# Altium schematic sheet Power.SchDoc(Designator)
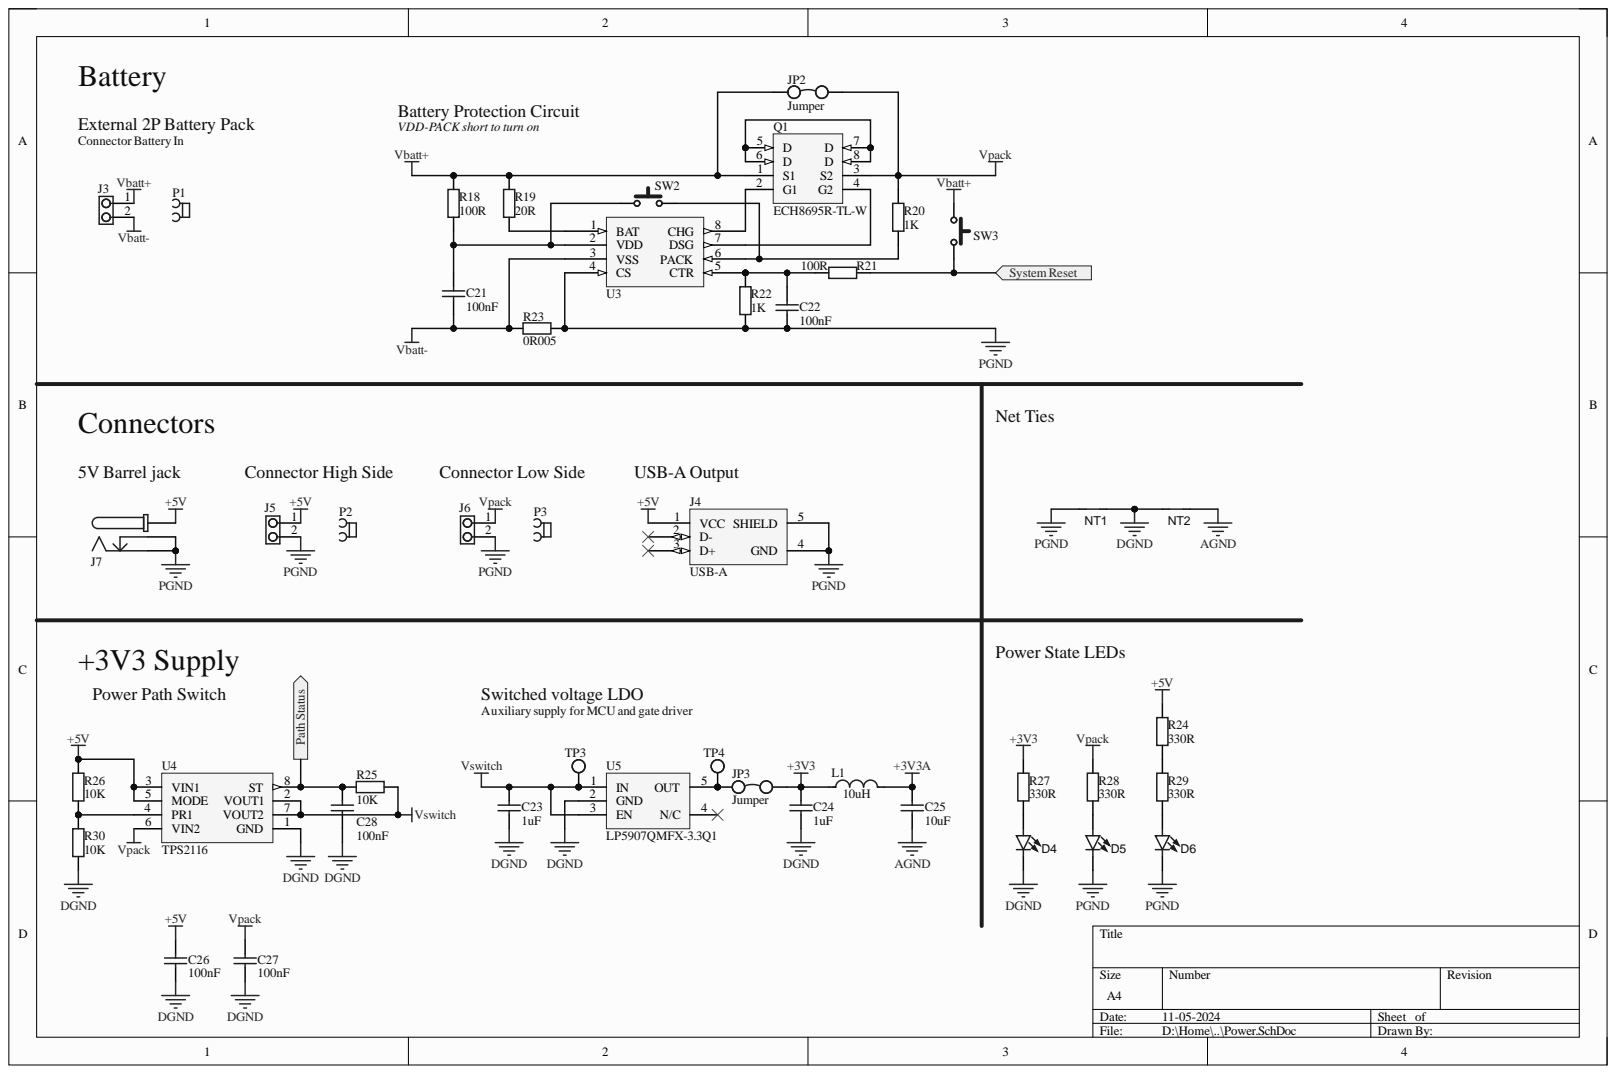
[diagram p0: Power.SchDoc]

## Parts
C21  100nF  [CAP0603]
C22  100nF  [CAP0603]
C23  1uF  [CAP0603]
C24  1uF  [CAP0603]
C25  10uF  [CAP0603]
C26  100nF  [CAP0603]
C27  100nF  [CAP0603]
C28  100nF  [CAP0603]
D4  Value  [LED0603]  (value not drawn)
D5  Value  [LED0603]  (value not drawn)
D6  Value  [LED0603]  (value not drawn)
J3  691313510002  [WR-TBL-5.08/2-M]  (value not drawn)
J4  USB-A  [USB-A-FEMALE]
J5  691313510002  [WR-TBL-5.08/2-M]  (value not drawn)
J6  691313510002  [WR-TBL-5.08/2-M]  (value not drawn)
J7  DC Power Jack  [DC Power Jack Right Angled]  (value not drawn)
JP2  Jumper  [PIN-1X2]
JP3  Jumper  [PIN-1X2]
L1  value 10uH  [IND0603]  (hidden comment: Inductor)
NT1  NetTie_1-1  [NETTIE_1-0.2MM]  (value not drawn)
NT2  NetTie_1-1  [NETTIE_1-0.2MM]  (value not drawn)
P1  691351500002  [WR-TBL-5.08/2-F]  (value not drawn)  (mechanical)
P2  691351500002  [WR-TBL-5.08/2-F]  (value not drawn)  (mechanical)
P3  691351500002  [WR-TBL-5.08/2-F]  (value not drawn)  (mechanical)
Q1  ECH8695R-TL-W  [SOT-28FL]
R18  100R  [RES0603]
R19  20R  [RES0603]
R20  1K  [RES0603]
R21  100R  [RES0603]
R22  1K  [RES0603]
R23  0R005  [RES1206_KELVIN]
R24  330R  [RES0603]
R25  10K  [RES0603]
R26  10K  [RES0603]
R27  330R  [RES0603]
R28  330R  [RES0603]
R29  330R  [RES0603]
R30  10K  [RES0603]
SW2  PTS526 Button  [PTS526 SMG15J SMTR2 LFS]  (value not drawn)
SW3  PTS526 Button  [PTS526 SMG15J SMTR2 LFS]  (value not drawn)
TP3  Test Point  [TP-1.5HOLE]  (value not drawn)
TP4  Test Point  [TP-1.5HOLE]  (value not drawn)
U3  BQ2980  [X2QFN-8]  (value not drawn)
U4  TPS2116  [SOTFL50P160X60-8N]
U5  LP5907QMFX-3.3Q1  [SOT23-5]

## Ports
Path Status, System Reset

## Nets
+3V3: C24.2 JP3.2 L1.1 R27.2
+3V3A: C25.2 L1.2
+5V: C26.2 J4.1(VCC) J5.1 J7.1 R24.2 R26.2 U4.3(VIN1) U4.5(MODE)
AGND: C25.1 NT2.2
DGND: C23.1 C24.1 C26.1 C27.1 C28.1 D4.1 NT1.2 NT2.1 R30.1 U4.1(GND) U5.2(GND)
PGND: C22.1 D5.1 D6.1 J4.4(GND) J4.5(SHIELD) J5.2 J6.2 J7.2 J7.3 NT1.1 R22.1 R23.2 U3.4(CS)
Vbatt+: J3.1 JP2.1 Q1.1(S1) R18.2 R19.2 SW3.1
Vbatt-: C21.1 J3.2 R23.1 U3.3(VSS)
Vpack: C27.2 J6.1 JP2.2 Q1.3(S2) R20.2 R28.2 U4.6(VIN2)
Vswitch: C23.2 R25.1 TP3.1 U4.2(VOUT1) U4.7(VOUT2) U5.1(IN) U5.3(EN)
Path Status: C28.2 R25.2 U4.8(ST) ; port Path Status
System Reset: R21.2 SW3.2 ; port System Reset
NetC21_2: C21.2 R18.1 SW2.1 U3.2(VDD)
NetC22_2: C22.2 R21.1 R22.2 U3.5(CTR)
NetD4_2: D4.2 R27.1
NetD5_2: D5.2 R28.1
NetD6_2: D6.2 R29.1
NetJ4_2: J4.2(D-)
NetJ4_3: J4.3(D+)
NetJP3_1: JP3.1 TP4.1 U5.5(OUT)
NetQ1_2: Q1.2(G1) U3.8(CHG)
NetQ1_4: Q1.4(G2) U3.7(DSG)
NetQ1_5: Q1.5(D) Q1.6(D) Q1.7(D) Q1.8(D)
NetR19_1: R19.1 U3.1(BAT)
NetR20_1: R20.1 SW2.2 U3.6(PACK)
NetR24_1: R24.1 R29.2
NetR26_1: R26.1 R30.2 U4.4(PR1)
NetU5_4: U5.4(N/C)

## Library data of the parts
C21 C22 C26-C28 = 100nF: Capacitor; Component Kind: Standard; Value: 100nF; Library Name: UPS_Schlib.SchLib
C23 C24 = 1uF: Capacitor; Component Kind: Standard; Value: 1uF; Library Name: UPS_Schlib.SchLib
C25 = 10uF: Ceramic Capacitor, Multilayer, Ceramic, 6.3V, 20% +Tol, 20% -Tol, X5R, 15% TC, 10uF, Surface Mount, 0603; Manufacturer: Samsung; Manufacturer Part Number: CL10A106MQ8NNND; Multilayer: Yes; Capacitor Type: Ceramic; Case/Package: 0603; Category: Ceramic Capacitors; Component Kind: Standard; ComponentLink1Description: https://datasheet.ciiva.com:443/pdfs/VipMasterIC/IC/SEMS/SEMS-S-A0008522793/SEMS-S-A0008522909-1.pdf?src-supplier=IHS+Markit; ComponentLink1URL: https://datasheet.ciiva.com:443/pdfs/VipMasterIC/IC/SEMS/SEMS-S-A0008522793/SEMS-S-A0008522909-1.pdf?src-supplier=IHS+Markit; Dielectric Material: Ceramic; Height: 0.8mm; JESD-609 Code: e3; Length: 1.6mm; Max Operating Temperature: 85°C; Min Operating Temperature: -55°C; Mounting Technology: Surface Mount; Package Shape: Rectangular; Package Style: SMTMeter; Packaging: Tape and Reel; Pins: 2; Pricing: 1=0.1, 10=0.066, 50=0.066, 100=0.031, 1000=0.019, 10000=0.014 (USD); Rated DC Voltage (URdc): 6.3V; Reach SVHC Compliant: Yes; RoHS: Yes; RoHS Compliant: Yes; Stock: 103768; Supplier: Mouser; Supplier Part Number: 187-CL10A106MQ8NNND; Temperature Characteristics Code: X5R; Terminal Finish: Nickel; Termination: Wraparound; Tolerance  (fill in as +-): 20%; Tolerance (fill in as +-): 20%; Value: 10uF; Width: 0.8mm; Library Name: UPS_Schlib.SchLib
D4-D6 = LED Green: Single Color LED, Bright Green, Water Clear, 1.1mm; Manufacturer: Wurth Electronics; Manufacturer Part Number: 150060VS75000; Channels: 1; Forward Current: 30mA; Luminous Intensity-Nom: 40mcd; Overall Height: 0.7mm; Shape: Rectangular; Viewing Angle: 140°; Category: LEDs; Color: Green; Component Kind: Standard; ComponentLink1Description: https://datasheet.ciiva.com:443/pdfs/VipMasterIC/IC/MIDC/MIDC-S-A0007768636/MIDC-S-A0007768636-1.pdf?src-supplier=IHS+Markit; ComponentLink1URL: https://datasheet.ciiva.com:443/pdfs/VipMasterIC/IC/MIDC/MIDC-S-A0007768636/MIDC-S-A0007768636-1.pdf?src-supplier=IHS+Markit; ComponentLink2Description: https://datasheet.ciiva.com/29562/150060vs75000-29562919.pdf?src-supplier=Digikey; ComponentLink2URL: https://datasheet.ciiva.com/29562/150060vs75000-29562919.pdf?src-supplier=Digikey; Lens Style: WATER CLEAR; Max Operating Temperature: 85°C; Min Operating Temperature: -40°C; Mounting Technology: Surface Mount; Optoelectronic Device Type: SINGLE COLOR LED; Packaging: Tape and Reel; Pins: 2; Pricing: 1=0.15, 10=0.15, 50=0.142, 100=0.122, 1000=0.116, 10000=0.116 (USD); Reach SVHC Compliant: Yes; RoHS: Yes; RoHS Compliant: Yes; Size: 1.1mm; Stock: 96633; Supplier: Mouser; Supplier Part Number: 710-150060VS75000; Terminal Pitch: 1.6mm; Library Name: UPS_Schlib.SchLib
J3 J5 J6 = 691313510002: Wurth Elektronik, 2 Pin Pluggable Terminal Block, Male; Manufacturer: Wurth Electronics; Manufacturer Series: 313; Current Rating: 20A; Fastening Method: CONNECTOR; Number of Decks: 1; Number of Ways: 2; Safety Approval: UL,VDE; Voltage Rating: 300V; Category: Terminal Blocks; Component Kind: Standard; ComponentLink1Description: https://datasheet.ciiva.com:443/pdfs/VipMasterIC/IC/MIDC/MIDC-S-A0008053020/MIDC-S-A0008053020-1.pdf?src-supplier=IHS+Markit; ComponentLink1URL: https://datasheet.ciiva.com:443/pdfs/VipMasterIC/IC/MIDC/MIDC-S-A0008053020/MIDC-S-A0008053020-1.pdf?src-supplier=IHS+Markit; ComponentLink2Description: https://datasheet.ciiva.com/8043/1442232-8043521.pdf?src-supplier=Element14; ComponentLink2URL: https://datasheet.ciiva.com/8043/1442232-8043521.pdf?src-supplier=Element14; ComponentLink3Description: https://datasheet.ciiva.com/795/6913135100xx-795821.pdf?src-supplier=Digikey; ComponentLink3URL: https://datasheet.ciiva.com/795/6913135100xx-795821.pdf?src-supplier=Digikey; Datasheet Link: https://www.we-online.de/katalog/datasheet/6913135100xx.pdf; Height: 8.5mm; Manufacturer Part Number: 691313510002; Manufacturer_Name: Wurth Elektronik; Manufacturer_Part_Number: 691313510002; Mounting Technology: Board; Mouser Part Number: 710-691313510002; Mouser Price/Stock: https://www.mouser.co.uk/ProductDetail/Wurth-Elektronik/691313510002?qs=2kOmHSv6VfR6BrMDgUh3kQ%3D%3D; Number of Rows: 1; Pricing: 1=0.35, 10=0.315, 50=0.295, 100=0.295, 1000=0.295, 10000=0.294 (USD); Reach SVHC Compliant: Yes; RoHS: Yes; RoHS Compliant: Yes; Stock: 1885; Supplier: Mouser; Supplier Part Number: 710-691313510002; Terminal and Terminal Block Type: STRIP TERMINAL BLOCK; Library Name: UPS_Schlib.SchLib
J4 = USB-A: USB Connector; Manufacturer: Bel; Manufacturer Part Number: SS-52100-001; Category: Connectors; Component Kind: Standard; ComponentLink1Description: https://datasheet.ciiva.com:443/pdfs/VipMasterIC/IC/BELF/BELF-S-A0007110717/BELF-S-A0007110717-1.pdf?src-supplier=IHS+Markit; ComponentLink1URL: https://datasheet.ciiva.com:443/pdfs/VipMasterIC/IC/BELF/BELF-S-A0007110717/BELF-S-A0007110717-1.pdf?src-supplier=IHS+Markit; ComponentLink2Description: http://www.farnell.com/datasheets/2813579.pdf?src-supplier=Farnell; ComponentLink2URL: http://www.farnell.com/datasheets/2813579.pdf?src-supplier=Farnell; ComponentLink3Description: https://belfuse.com/resources/drawings/stewartconnector/dr-stw-ss-52100-001.pdf?src-supplier=Digikey; ComponentLink3URL: https://belfuse.com/resources/drawings/stewartconnector/dr-stw-ss-52100-001.pdf?src-supplier=Digikey; ComponentLink4Description: http://www.farnell.com/datasheets/2813579.pdf?src-supplier=Newark; ComponentLink4URL: http://www.farnell.com/datasheets/2813579.pdf?src-supplier=Newark; ComponentLink5Description: https://datasheet.octopart.com/SS-52100-001-Bel-Fuse-datasheet-137355466.pdf?src-supplier=Sager+Electronics; ComponentLink5URL: https://datasheet.octopart.com/SS-52100-001-Bel-Fuse-datasheet-137355466.pdf?src-supplier=Sager+Electronics; Pricing: 1=0.57, 10=0.346, 50=0.346, 100=0.286, 1000=0.252, 10000=0.252 (USD); RoHS: Yes; RoHS Compliant: Yes; Stock: 6440; Supplier: Mouser; Supplier Part Number: 530-SS-52100-001; Library Name: UPS_Schlib.SchLib
J7 = DC Power Jack: CIRCULAR DC POWER CONNECTOR; Manufacturer: Wurth Electronics; Manufacturer Part Number: 694106301002; Connector Type: Circular,Power; Category: Power Connectors; Component Kind: Standard; ComponentLink1Description: https://datasheet.ciiva.com:443/pdfs/VipMasterIC/IC/MIDC/MIDC-S-A0001192814/MIDC-S-A0001192814-1.pdf?src-supplier=IHS+Markit; ComponentLink1URL: https://datasheet.ciiva.com:443/pdfs/VipMasterIC/IC/MIDC/MIDC-S-A0001192814/MIDC-S-A0001192814-1.pdf?src-supplier=IHS+Markit; ComponentLink2Description: http://www.mouser.com/ds/2/445/6941xx301002-469315.pdf?src-supplier=Mouser; ComponentLink2URL: http://www.mouser.com/ds/2/445/6941xx301002-469315.pdf?src-supplier=Mouser; ComponentLink3Description: http://www.farnell.com/cad/2130967.pdf?src-supplier=Element14; ComponentLink3URL: http://www.farnell.com/cad/2130967.pdf?src-supplier=Element14; ComponentLink4Description: https://katalog.we-online.de/em/datasheet/6941xx301002.pdf?src-supplier=Digikey; ComponentLink4URL: https://katalog.we-online.de/em/datasheet/6941xx301002.pdf?src-supplier=Digikey; Contact Finish - Mating: NOT SPECIFIED; Reach SVHC Compliant: Yes; RoHS: Yes; RoHS Compliant: Yes; Stock: 1650; Supplier: Rs; Supplier Part Number: 1224879; Library Name: UPS_Schlib.SchLib
JP2 JP3 = Jumper: 2 pin jumper connection; Component Kind: Standard; Library Name: UPS_Schlib.SchLib
L1 = 10uH: General Purpose Inductor, 10uH, 20%, SMD 0603; Manufacturer: TDK; Manufacturer Part Number: MLZ1608E100MT000; Manufacturer Series: MLZ; Case/Size Code: 1608; Channels: 1; DC Resistance (DCR): 900mR; Inductor Application: POWER INDUCTOR; Max Current Rating: 40mA; Self Resonant Frequency: 30MHz; Shape/Size Description: RECTANGULAR PACKAGE; Shielding: Shielded; Test Frequency: 2MHz; Category: Fixed Inductors; Component Kind: Standard; ComponentLink1Description: https://datasheet.ciiva.com:443/pdfs/VipMasterIC/IC/TDKC/TDKCS07657/TDKCS07657-1.pdf?src-supplier=IHS+Markit; ComponentLink1URL: https://datasheet.ciiva.com:443/pdfs/VipMasterIC/IC/TDKC/TDKCS07657/TDKCS07657-1.pdf?src-supplier=IHS+Markit; Inductance-Nom (L): 10uH; Inductor Type: GENERAL PURPOSE INDUCTOR; JESD-609 Code: e3; Max Operating Temperature: 125°C; Min Operating Temperature: -55°C; Pins: 2; Pricing: 783=0.0324 (USD); Reach SVHC Compliant: Yes; RoHS: Yes; RoHS Compliant: Yes; Stock: 783; Supplier: Arrow Electronics; Supplier Part Number: MLZ1608E100MT000; Surface Mount: Yes; Terminal Finish: Tin; Terminal Placement: Dual Ended; Termination: Wraparound; Tolerance: 20%; Library Name: UPS_Schlib.SchLib
NT1 NT2 = NetTie_1-1: NetTie 1 -> 1; ID: 22; Inventory_Category: Other; Value: NetTie 1-1 0.2mm; Component Kind: Net Tie; Part Class: NetTie; Technology: SMT; Library Name: UPS_Schlib.SchLib
P1-P3 = 691351500002: Wurth Elektronik, 2 Pin Pluggable Terminal Block, Female; Manufacturer: Wurth Electronics; Manufacturer Series: 3515; Category: Terminal Blocks; ComponentLink1Description: https://datasheet.ciiva.com:443/pdfs/VipMasterIC/IC/MIDC/MIDC-S-A0008053020/MIDC-S-A0008053020-1.pdf?src-supplier=IHS+Markit; ComponentLink1URL: https://datasheet.ciiva.com:443/pdfs/VipMasterIC/IC/MIDC/MIDC-S-A0008053020/MIDC-S-A0008053020-1.pdf?src-supplier=IHS+Markit; ComponentLink2Description: https://datasheet.ciiva.com/8043/1442232-8043521.pdf?src-supplier=Element14; ComponentLink2URL: https://datasheet.ciiva.com/8043/1442232-8043521.pdf?src-supplier=Element14; ComponentLink3Description: https://datasheet.ciiva.com/795/6913135100xx-795821.pdf?src-supplier=Digikey; ComponentLink3URL: https://datasheet.ciiva.com/795/6913135100xx-795821.pdf?src-supplier=Digikey; ComponentLink4Description: https://datasheet.ciiva.com:443/pdfs/VipMasterIC/IC/MIDC/MIDCS16685/MIDCS16685-1.pdf?src-supplier=IHS+Markit; ComponentLink4URL: https://datasheet.ciiva.com:443/pdfs/VipMasterIC/IC/MIDC/MIDCS16685/MIDCS16685-1.pdf?src-supplier=IHS+Markit; ComponentLink5Description: https://datasheet.ciiva.com/7992/624595-7992355.pdf?src-supplier=Element14; ComponentLink5URL: https://datasheet.ciiva.com/7992/624595-7992355.pdf?src-supplier=Element14; ComponentLink6Description: https://datasheet.ciiva.com/796/6913515000xx-796118.pdf?src-supplier=Digikey; ComponentLink6URL: https://datasheet.ciiva.com/796/6913515000xx-796118.pdf?src-supplier=Digikey; ComponentLink7Description: https://datasheet.ciiva.com/48686/001088727-da-01-en-wr-tbl-terminal-block351-691351500002-48686810.pdf?src-supplier=Conrad; ComponentLink7URL: https://datasheet.ciiva.com/48686/001088727-da-01-en-wr-tbl-terminal-block351-691351500002-48686810.pdf?src-supplier=Conrad; Manufacturer Part Number: 691351500002; Pricing: 1=1.03, 10=0.919, 50=0.87, 100=0.87, 1000=0.87, 10000=0.87 (USD); Reach SVHC Compliant: No; RoHS: Yes; RoHS Compliant: Yes; Stock: 2108; Supplier: Mouser; Supplier Part Number: 710-691351500002; Terminal and Terminal Block Type: BARRIER STRIP TERMINAL BLOCK; Library Name: UPS_Schlib.SchLib
Q1 = ECH8695R-TL-W: 1-2 Cells Lithium-ion Battery Protection; Manufacturer_Name: onsemi; Arrow Part Number: ECH8695R-TL-W; Arrow Price/Stock: https://www.arrow.com/en/products/ech8695r-tl-w/on-semiconductor?region=europe; Component Kind: Standard; Datasheet Link: https://datasheet.datasheetarchive.com/originals/distributors/DKDS-17/338047.pdf; Height: 0.95mm; Manufacturer_Part_Number: ECH8695R-TL-W; Mouser Part Number: 863-ECH8695R-TL-W; Mouser Price/Stock: https://www.mouser.co.uk/ProductDetail/ON-Semiconductor/ECH8695R-TL-W?qs=dbuNSGnowt2koJiK%2FkW5Eg%3D%3D; Library Name: UPS_Schlib.SchLib
R18 R21 = 100R: Resistor; Component Kind: Standard; Value: 100R; Library Name: UPS_Schlib.SchLib
R19 = 20R: Resistor; Component Kind: Standard; Value: 20R; Library Name: UPS_Schlib.SchLib
R20 R22 = 1K: Resistor; Component Kind: Standard; Value: 1K; Library Name: UPS_Schlib.SchLib
R23 = 0R005: Resistor Current Sense Metal Foil 1206 5m Ohm 1% 1W ±50ppm/°C T/R; Manufacturer: Eaton; Manufacturer Part Number: MFSA1206R0050FCM; Category: Chip SMD Resistors; Component Kind: Standard; Pricing: 1=0.21, 10=0.173, 50=0.173, 100=0.128, 1000=0.096, 10000=0.062 (USD); RoHS: No; Stock: 9199; Supplier Part Number: 504-MFSA1206R0050FCM; Temperature Coefficient: 50ppm/°C; Value: 0R005; Library Name: UPS_Schlib.SchLib
R24 R27-R29 = 330R: Resistor; Component Kind: Standard; Value: 330R; Library Name: UPS_Schlib.SchLib
R25 R26 R30 = 10K: Resistor; Component Kind: Standard; Value: 10K; Library Name: UPS_Schlib.SchLib
SW2 SW3 = PTS526 Button: PTS526 SMG15J SMTR2 LFS; Part Class: Other; CompType: DTSM 32N/SMD; Note: DIPTRONICS; Component Kind: Standard; Distributor: RET; Order Code: 52-01-71; Library Name: UPS_Schlib.SchLib
U3 = BQ2980: Battery Management High-side protector for single-cell li-ion and li-polymer batteries; Component Kind: Standard; Library Name: UPS_Schlib.SchLib
U5 = LP5907QMFX-3.3Q1: Integrated Circuit; Manufacturer_Name: Texas Instruments; Arrow Part Number: LP5907QMFX-3.3Q1; Arrow Price/Stock: https://www.arrow.com/en/products/lp5907qmfx-3.3q1/texas-instruments; Component Kind: Standard; Datasheet Link: http://www.ti.com/lit/gpn/lp5907-q1; Height: 1.45mm; Manufacturer_Part_Number: LP5907QMFX-3.3Q1; Mouser Part Number: 595-LP5907QMFX-3.3Q1; Mouser Price/Stock: https://www.mouser.co.uk/ProductDetail/Texas-Instruments/LP5907QMFX-3.3Q1?qs=asCBFxFfL1RsL2VYqGFsBQ%3D%3D; Library Name: UPS_Schlib.SchLib
TP3 TP4 = Test Point: Component Kind: Standard; Description: Test Point; Library Name: UPS_Schlib.SchLib
U4 = TPS2116: Component Kind: Standard; Library Name: UPS_Schlib.SchLib
